# Altium schematic sheet I2C.SchDoc
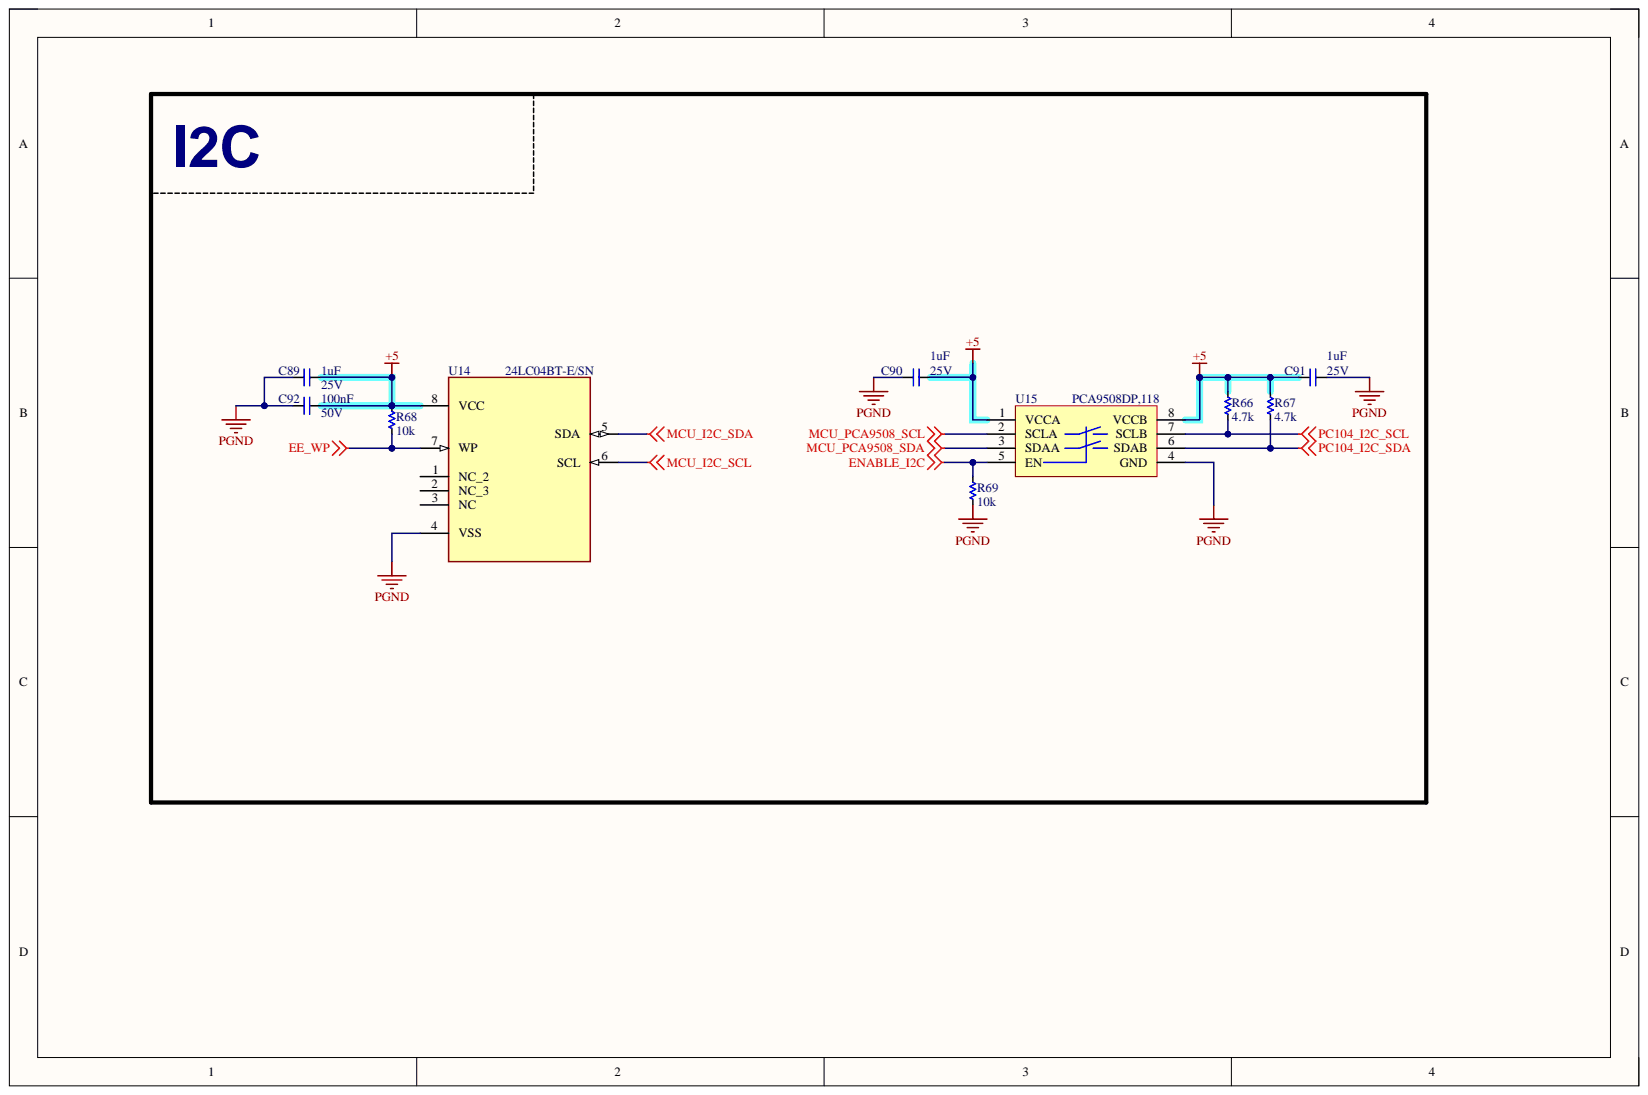
[diagram p0: I2C.SchDoc]

## Parts
C89  1uF  Voltage: 25V  [CAP_C_1608X80]
C90  1uF  Voltage: 25V  [CAP_C_1608X80]
C91  1uF  Voltage: 25V  [CAP_C_1608X80]
C92  100nF  Voltage: 50V  [CAP_C_1608X80]
R66  4.7k  [RES_C_1608X60]
R67  4.7k  [RES_C_1608X60]
R68  10k  [RES_C_1608X60]
R69  10k  [RES_C_1608X60]
U14  24LC04BT-E/SN  [SOIC_127]
U15  PCA9508DP,118  [TSOP8]

## Nets
+5: C89.1 C90.2 C91.2 C92.1 R66.1 R67.1 R68.1 U14.8(VCC) U15.1(VCCA) U15.8(VCCB)
EE_WP: R68.2 U14.7(WP)
ENABLE_I2C: R69.2 U15.5(EN)
MCU_I2C_SCL: U14.6(SCL)
MCU_I2C_SDA: U14.5(SDA)
MCU_PCA9508_SCL: U15.2(SCLA)
MCU_PCA9508_SDA: U15.3(SDAA)
PC104_I2C_SCL: R66.2 U15.7(SCLB)
PC104_I2C_SDA: R67.2 U15.6(SDAB)
PGND: C89.2 C90.1 C91.1 C92.2 R69.1 U14.4(VSS) U15.4(GND)

Not connected: U14 1(NC_2) 2(NC_3) 3(NC)

## Library data of the parts
C89-C92 = _CAP_C_2: CAP; Component Kind: Standard; Library Name: LABLIB.SchLib
R66-R69 = _RES_B_2_2: RES; Component Kind: Standard; Library Name: LABLIB.SchLib
U14 = 24LC04BT-E/SN: Component Kind: Standard; Library Name: KIENASIY123_LIB.IntLib
U15 = PCA9508DP,118: Component Kind: Standard; Library Name: EXP_HW_V3.SchLib
